# Altium schematic sheet TF_SPI_NAND.SchDoc(TF_SPI_NAND)
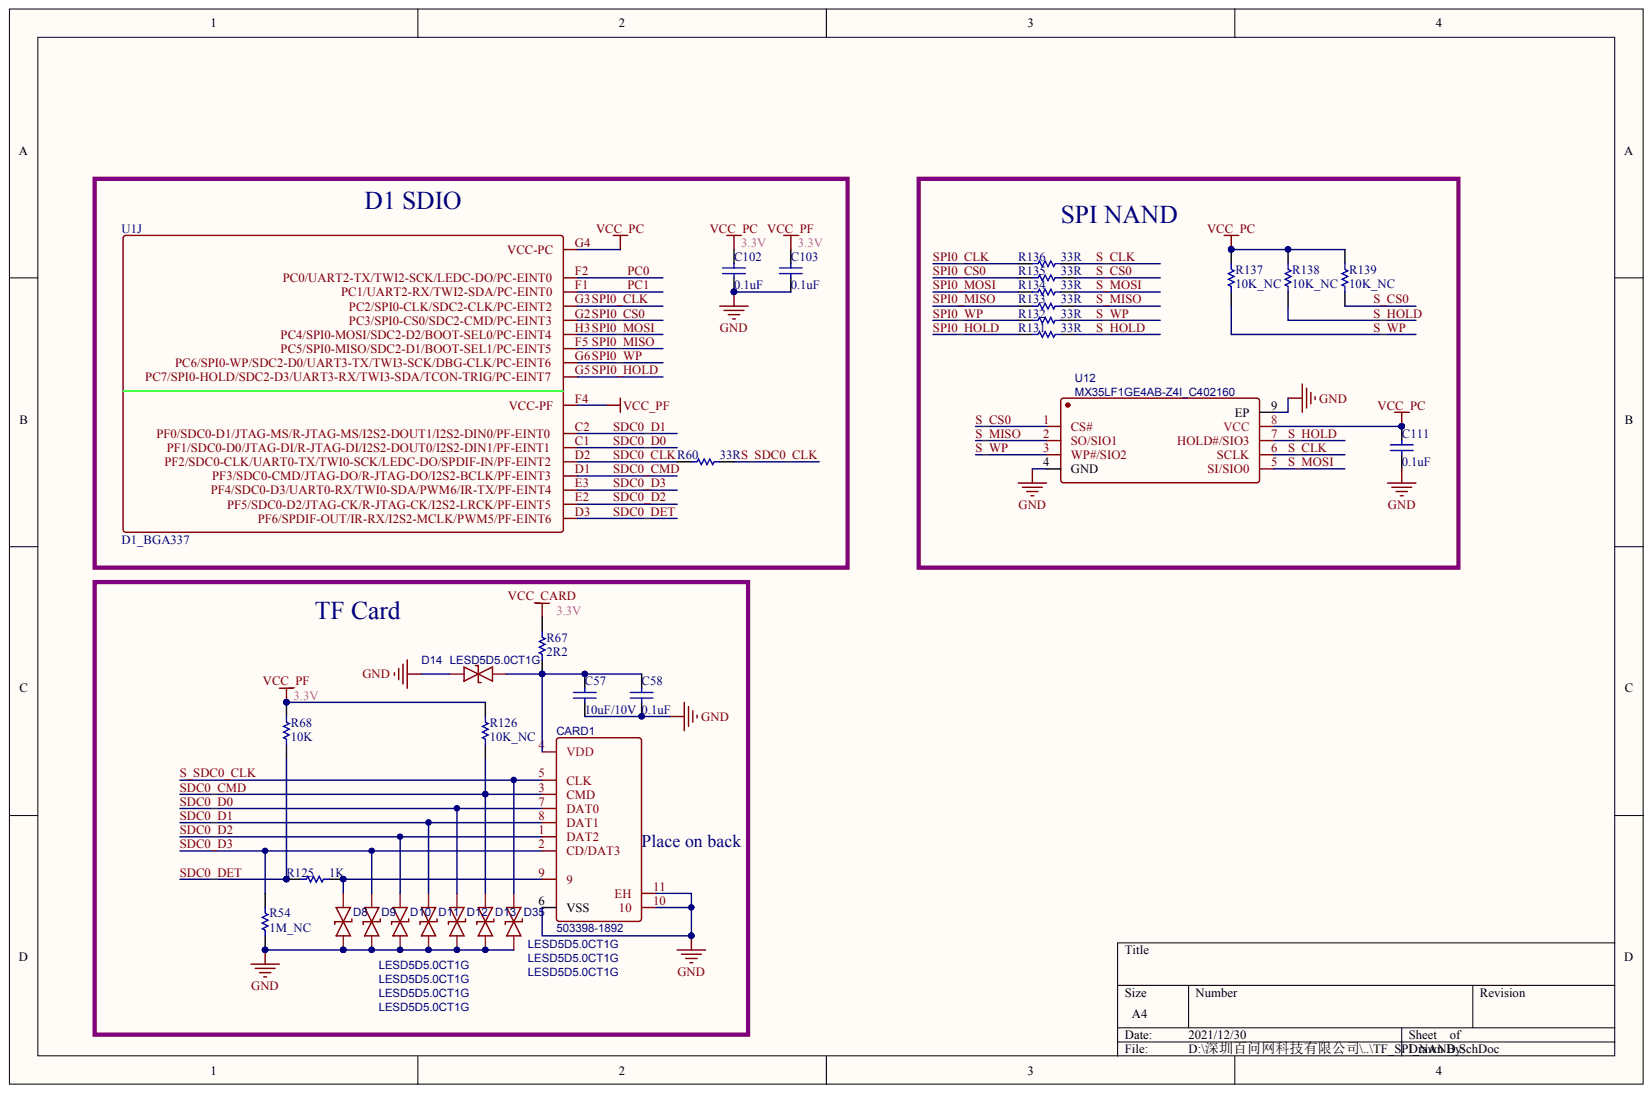
[diagram p0: TF_SPI_NAND.SchDoc]

## Parts
C57  10uF/10V
C58  0.1uF
C102  0.1uF
C103  0.1uF
C111  0.1uF
CARD1  503398-1892
D8  LESD5D5.0CT1G
D9  LESD5D5.0CT1G
D10  LESD5D5.0CT1G
D11  LESD5D5.0CT1G
D12  LESD5D5.0CT1G
D13  LESD5D5.0CT1G
D14  LESD5D5.0CT1G
D35  LESD5D5.0CT1G
R54  1M_NC
R60  33R
R67  2R2
R68  10K
R125  1K
R126  10K_NC
R131  33R
R132  33R
R133  33R
R134  33R
R135  33R
R136  33R
R137  10K_NC
R138  10K_NC
R139  10K_NC
U1  D1_BGA337  (part J)
U12  MX35LF1GE4AB-Z4I_C402160

## Nets
GND: C57.2 C58.2 C102.1 C103.2 C111.1 CARD1.6(VSS) CARD1.10 CARD1.11(EH) D8.1 D9.1 D10.1 D11.1 D12.1 D13.1 D14.2 D35.1 R54.2 U12.4(GND) U12.9(EP)
VCC_CARD: R67.2
VCC_PC: C102.2 C111.2 R137.1 R138.1 R139.1 U1.G4(VCC-PC) U12.8(VCC)
VCC_PF: C103.1 R68.1 R126.1 U1.F4(VCC-PF)
PC0: U1.F2(PC0/UART2-TX/TWI2-SCK/LEDC-DO/PC-EINT0)
PC1: U1.F1(PC1/UART2-RX/TWI2-SDA/PC-EINT0)
S_CLK: R136.2 U12.6(SCLK)
S_CS0: R135.2 R139.2 U12.1(CS#)
S_HOLD: R131.2 R138.2 U12.7(HOLD#/SIO3)
S_MISO: R133.2 U12.2(SO/SIO1)
S_MOSI: R134.2 U12.5(SI/SIO0)
S_SDC0_CLK: CARD1.5(CLK) D35.2 R60.1
S_WP: R132.2 R137.2 U12.3(WP#/SIO2)
SDC0_CLK: R60.2 U1.D2(PF2/SDC0-CLK/UART0-TX/TWI0-SCK/LEDC-DO/SPDIF-IN/PF-EINT2)
SDC0_CMD: CARD1.3(CMD) D13.2 R126.2 U1.D1(PF3/SDC0-CMD/JTAG-DO/R-JTAG-DO/I2S2-BCLK/PF-EINT3)
SDC0_D0: CARD1.7(DAT0) D12.2 U1.C1(PF1/SDC0-D0/JTAG-DI/R-JTAG-DI/I2S2-DOUT0/I2S2-DIN1/PF-EINT1)
SDC0_D1: CARD1.8(DAT1) D11.2 U1.C2(PF0/SDC0-D1/JTAG-MS/R-JTAG-MS/I2S2-DOUT1/I2S2-DIN0/PF-EINT0)
SDC0_D2: CARD1.1(DAT2) D10.2 U1.E2(PF5/SDC0-D2/JTAG-CK/R-JTAG-CK/I2S2-LRCK/PF-EINT5)
SDC0_D3: CARD1.2(CD/DAT3) D9.2 R54.1 U1.E3(PF4/SDC0-D3/UART0-RX/TWI0-SDA/PWM6/IR-TX/PF-EINT4)
SDC0_DET: R68.2 R125.2 U1.D3(PF6/SPDIF-OUT/IR-RX/I2S2-MCLK/PWM5/PF-EINT6)
SPI0_CLK: R136.1 U1.G3(PC2/SPI0-CLK/SDC2-CLK/PC-EINT2)
SPI0_CS0: R135.1 U1.G2(PC3/SPI0-CS0/SDC2-CMD/PC-EINT3)
SPI0_HOLD: R131.1 U1.G5(PC7/SPI0-HOLD/SDC2-D3/UART3-RX/TWI3-SDA/TCON-TRIG/PC-EINT7)
SPI0_MISO: R133.1 U1.F5(PC5/SPI0-MISO/SDC2-D1/BOOT-SEL1/PC-EINT5)
SPI0_MOSI: R134.1 U1.H3(PC4/SPI0-MOSI/SDC2-D2/BOOT-SEL0/PC-EINT4)
SPI0_WP: R132.1 U1.G6(PC6/SPI0-WP/SDC2-D0/UART3-TX/TWI3-SCK/DBG-CLK/PC-EINT6)
NetC57_1: C57.1 C58.1 CARD1.4(VDD) D14.1 R67.1
NetCARD1_9: CARD1.9 D8.2 R125.1

## Library data of the parts
C57 C58 C102 C103 C111 = (no symbol name): PartNumber: CAP100NF; Published: 8-Jun-2000; LatestRevisionDate: 17-Jul-2002; LatestRevisionNote: Re-released for DXP Platform.; PackageReference: 0402; Publisher: Altium Limited
  C57: Value: 10uF/10V
  C58 C102 C103 C111: Value: 0.1uF
CARD1 = (no symbol name): BOM_Manufacturer: MOLEX; Contributor: LCSC; BOM_Supplier: LCSC; BOM_Supplier Part: C428492; BOM_Manufacturer Part: 503398-1892; BOM_JLCPCB Part Class: Extended Part; spicePre: C; spiceSymbolName: 503398-1892
D8-D14 D35 = (no symbol name): BOM_Manufacturer: Leshan Radio; Contributor: LCSC; BOM_Paste Type: expand; BOM_Supplier: LCSC; BOM_Supplier Part: C383211; BOM_Manufacturer Part: LESD5D5.0CT1G; spicePre: D; spiceSymbolName: LESD5D5.0CT1G
R54 R60 R67 R68 R125 R126 R131-R139 = (no symbol name): PartNumber: RES2.2K; Published: 8-Jun-2000; LatestRevisionDate: 17-Jul-2002; LatestRevisionNote: Re-released for DXP Platform.; PackageReference: 0402; Publisher: Altium Limited
  R54: Value: 1M_NC
  R60 R131-R136: Value: 33R
  R67: Value: 2R2
  R68: Value: 10K
  R125: Value: 1K
  R126 R137-R139: Value: 10K_NC
U12 = (no symbol name): BOM_Manufacturer: Macronix; Contributor: LCSC; BOM_Supplier: LCSC; BOM_Supplier Part: C402160; BOM_Manufacturer Part: MX35LF1GE4AB-Z4I; BOM_JLCPCB Part Class: Extended Part; spicePre: U; spiceSymbolName: MX35LF1GE4AB-Z4I_C402160
